# Altium schematic sheet Main.SchDoc
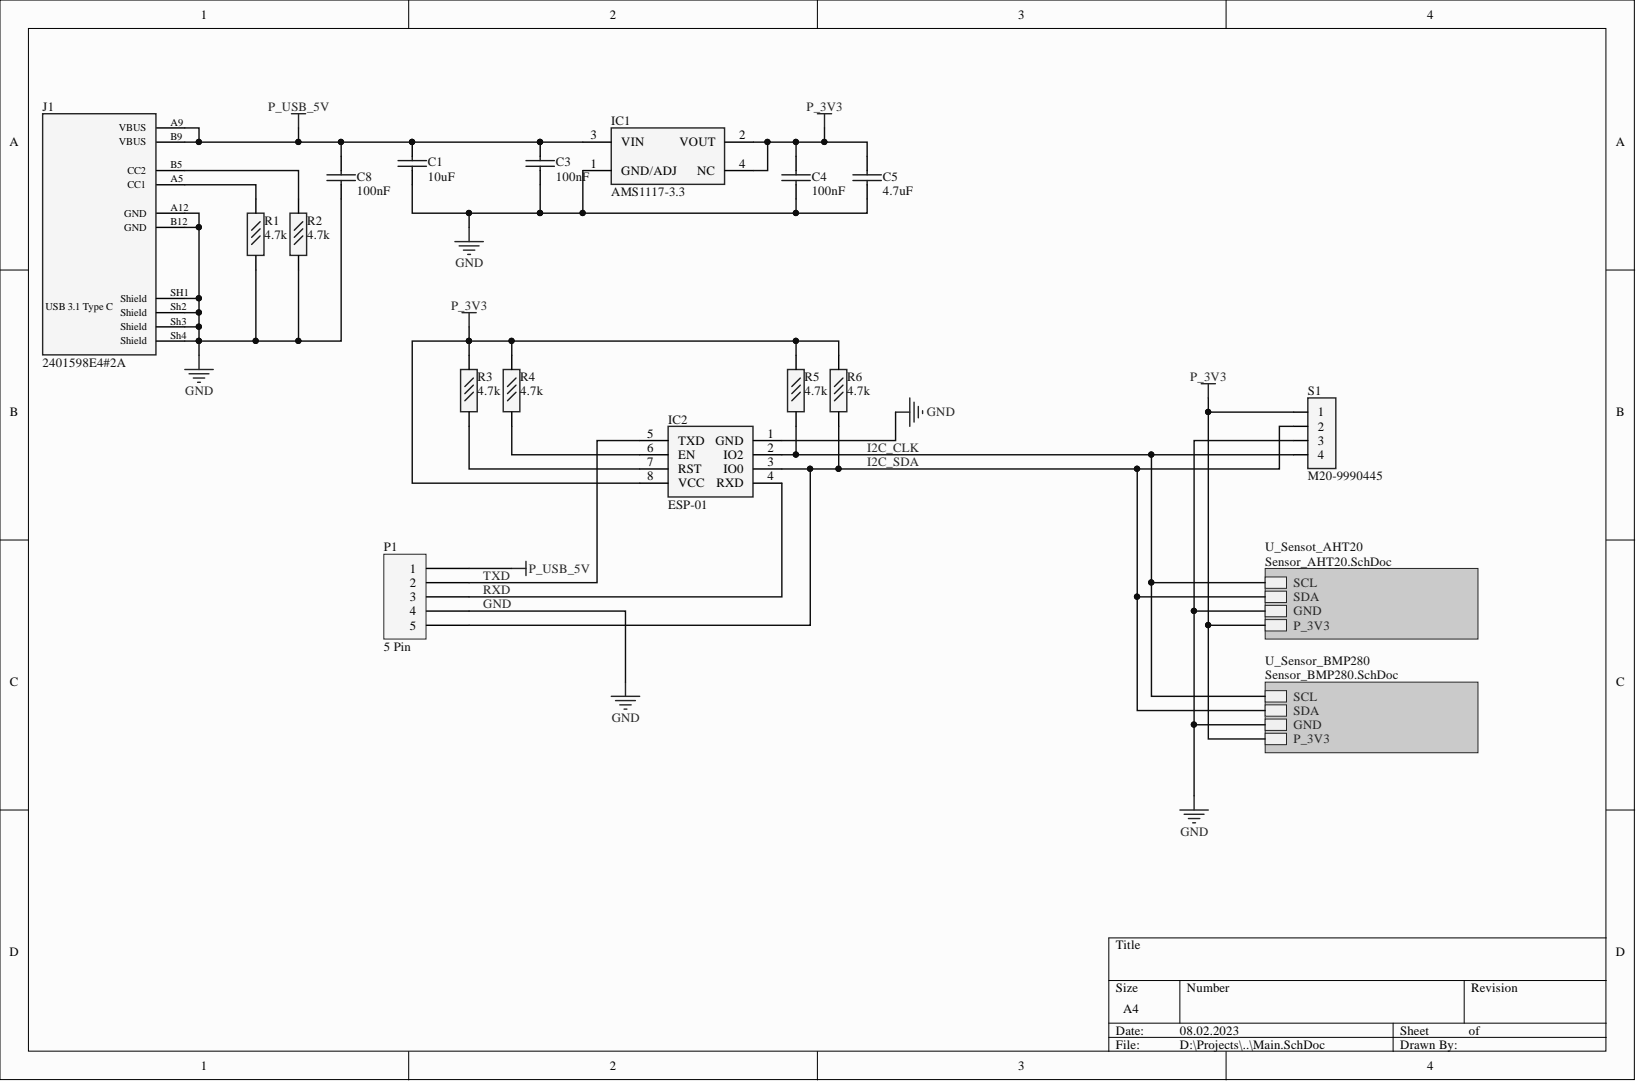
[diagram p0: Main.SchDoc]

## Parts
C1  10uF  [1206c]
C3  100nF  [0603c]
C4  100nF  [0603c]
C5  4.7uF  [1206c]
C8  100nF  [0603c]
IC1  AMS1117-3.3  [SOT229P700X180-4N]
IC2  ESP-01  [ESP01]
J1  2401598E4#2A  Sub-Family: USB 3.1  [USB-C-6pins]
P1  5 Pin  [PIN_HEADER_2_54_180_1X05_SMD]
R1  4.7k  [0603r]
R2  4.7k  [0603r]
R3  4.7k  [0603r]
R4  4.7k  [0603r]
R5  4.7k  [0603r]
R6  4.7k  [0603r]
S1  M20-9990445  [M20-9990445]

## Nets
P_3V3: C4.1 C5.1 IC1.2(VOUT) IC1.4(NC) IC2.8(VCC) R3.1 R4.1 R5.1 R6.1 S1.1
P_USB_5V: C1.1 C3.1 C8.1 IC1.3(VIN) J1.A9(VBUS) J1.B9(VBUS) P1.1
GND: C1.2 C3.2 C4.2 C5.2 C8.2 IC1.1(GND/ADJ) IC2.1(GND) J1.A12(GND) J1.B12(GND) J1.SH1(Shield) J1.Sh2(Shield) J1.Sh3(Shield) J1.Sh4(Shield) P1.4 R1.2 R2.2 S1.3
I2C_CLK: IC2.2(IO2) R5.2 S1.4
I2C_SDA: IC2.3(IO0) P1.5 R6.2 S1.2
RXD: IC2.4(RXD) P1.3
TXD: IC2.5(TXD) P1.2
NetIC2_6: IC2.6(EN) R4.2
NetIC2_7: IC2.7(RST) R3.2
NetJ1_A5: J1.A5(CC1) R1.1
NetJ1_B5: J1.B5(CC2) R2.1

## Library data of the parts
C1 = 10uF 16V 10% 1206 X7R: Company: Yageo; Order Code: CC1206KKX7R7BB106; Type: Capacitor; Component Kind: Standard; Description: 10uF 16V 10% 1206 X7R; Value: 10uF; Library Name: CAPACITOR_dropbox.SchLib
C3 C4 C8 = 100nF 25V 0603: Company: Yageo; ID: B1153B11114; Order Code: CC0603KRX7R8BB104; TSKD: FS-CM_StandDet_Capacitor_CeramicSMD(100nF_25_10%_0603_X7R); Type: Capacitor; Component Kind: Standard; Description: 100nF 25V 0603; Supplier 1: Digi-Key; Supplier Part Number 1: 311-1341-1-ND; Value: 100nF; Library Name: CAPACITOR_dropbox.SchLib
C5 = 4.7uF 16V 10% 1206 X5R: Company: Taiyo Yuden; Order Code: EMK316BJ475KLHT; Type: Capacitor; Component Kind: Standard; Description: 4.7uF 16V 10% 1206 X5R; Mounting: SMD; Supplier 1: Arrow Electronics Inc.; Supplier Part Number 1: EMK316BJ475KLHT; Value: 4.7uF; Library Name: CAPACITOR_dropbox.SchLib
IC1 = AMS1117-3.3: Integrated Circuit; Manufacturer_Name: Advanced; Component Kind: Standard; Datasheet Link: https://datasheet.datasheetarchive.com/originals/distributors/Datasheets-28/DSA-550594.pdf; Height: 1.8mm; Library Name: SamacSys.SchLib; Manufacturer_Part_Number: AMS1117-3.3
IC2 = ESP-01: Integrated Circuit; Manufacturer_Name: Ai-Thinker; Component Kind: Standard; Datasheet Link: https://docs.ai-thinker.com/_media/esp8266/docs/esp-01_product_specification_en.pdf; Manufacturer_Part_Number: ESP-01
J1 = USBC-6pins: Company: China; Order Code: No; Component Kind: Standard; ComponentLink1Description: aliexpress; ComponentLink1URL: https://www.aliexpress.com/item/32966491026.html?spm=a2g0o.order_list.0.0.6d0c1802PiIxPz&dp=bb148346dcbdf252ea9aa09c1abe45ac&af=553779&cv=47843&afref=https%3A%2F%2Fwww.aliexpress.com%2F&mall_affr=pr3&utm_source=admitad&utm_medium=cpa&utm_campaign=553779&utm_content=47843&dp=bb148346dcbdf252ea9aa09c1abe45ac&af=553779&cv=47843&afref=https%3A%2F%2Fwww.aliexpress.com%2F&mall_affr=pr3&utm_source=admitad&utm_medium=cpa&utm_campaign=553779&utm_content=47843&aff_fcid=67179ceea6b443d9be6969c016c4a473-1667843561684-02051-_ePNSNV&aff_fsk=_ePNSNV&aff_platform=portals-tool&sk=_ePNSNV&aff_trace_key=67179ceea6b443d9be6969c016c4a473-1667843561684-02051-_ePNSNV&terminal_id=58be3c4b0a504a0bbbe13b6506425774&afSmartRedirect=y; Library Name: USB.SchLib; Supplier 1: Digi-Key; Type: Connector
R1-R6 = 4.7k 5% 100 0603: Company: Yageo; ID: B112CV1111J; Order Code: RC0603JR-074K7L; TSKD: FS-CM_StandDet_Resistor_SMD(4K7_5%_100_0603); Component Kind: Standard; Description: 4.7k 5% 100 0603; Library Name: RESISTORs_Home.SchLib; Supplier 1: Digi-Key; Supplier Part Number 1: 311-4.7KGRDKR-ND; Type: Resistor; Value: 4.7k
S1 = M20-9990445: 4pin 2.54 vertical; Company: Harwin; Component Kind: Standard; Library Name: Headers.SchLib; Mounting: TH; Order Code: M20-9990445; Type: Connector; Value: M20-9990445
P1 = 5 Pin: Component Kind: Standard; Library Name: Headers.SchLib
